# Altium schematic sheet [8]-IR_BACKLIGHT.SchDoc([8]-IR_BACKLIGHT)
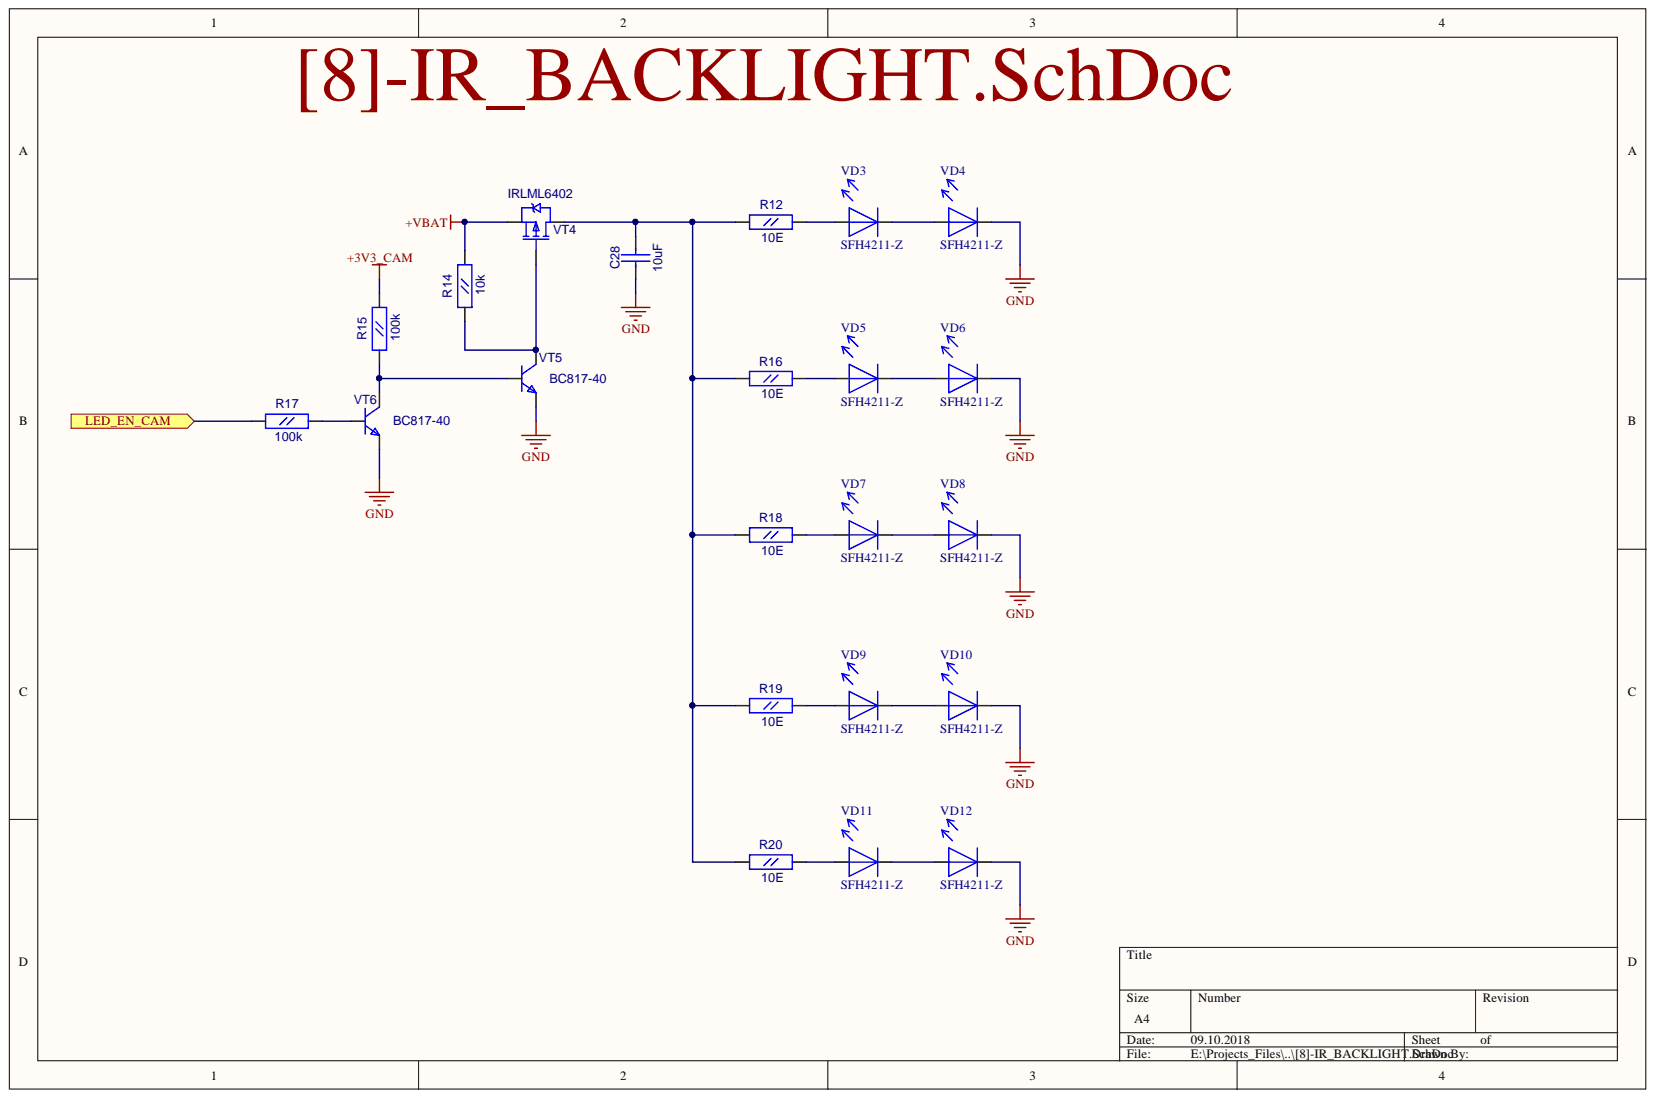
[diagram p0: [8]-IR_BACKLIGHT.SchDoc]

## Parts
C28  10uF  [CC0805]
R12  10E  [RC0603]
R14  10k  [RC0603]
R15  100k  [RC0603]
R16  10E  [RC0603]
R17  100k  [RC0603]
R18  10E  [RC0603]
R19  10E  [RC0603]
R20  10E  [RC0603]
VD3  SFH4211-Z  [LED_3528]
VD4  SFH4211-Z  [LED_3528]
VD5  SFH4211-Z  [LED_3528]
VD6  SFH4211-Z  [LED_3528]
VD7  SFH4211-Z  [LED_3528]
VD8  SFH4211-Z  [LED_3528]
VD9  SFH4211-Z  [LED_3528]
VD10  SFH4211-Z  [LED_3528]
VD11  SFH4211-Z  [LED_3528]
VD12  SFH4211-Z  [LED_3528]
VT4  IRLML6402  [SOT-23(123)]
VT5  BC817-40  [SOT-23(123)]
VT6  BC817-40  [SOT-23(123)]

## Ports
LED_EN_CAM

## Nets
+3V3_CAM: R15.2
+VBAT: R14.2 VT4.2
GND: C28.1 VD4.2 VD6.2 VD8.2 VD10.2 VD12.2 VT5.2 VT6.2
LED_EN_CAM: R17.1 ; port LED_EN_CAM
NetC28_2: C28.2 R12.1 R16.1 R18.1 R19.1 R20.1 VT4.3
NetR12_2: R12.2 VD3.1
NetR14_1: R14.1 VT4.1 VT5.3
NetR15_1: R15.1 VT5.1 VT6.3
NetR16_2: R16.2 VD5.1
NetR17_2: R17.2 VT6.1
NetR18_2: R18.2 VD7.1
NetR19_2: R19.2 VD9.1
NetR20_2: R20.2 VD11.1
NetVD3_2: VD3.2 VD4.1
NetVD5_2: VD5.2 VD6.1
NetVD7_2: VD7.2 VD8.1
NetVD9_2: VD9.2 VD10.1
NetVD11_2: VD11.2 VD12.1

## Library data of the parts
C28 = CC0805: Value: 10uF; Description: CC0805; Component Kind: Standard; Library Name: fizik_lib.SchLib
R12 R14-R20 = RC0603: Description: RC0603; Component Kind: Standard; Library Name: fizik_lib.SchLib
  R12 R16 R18-R20: Value: 10E
  R14: Value: 10k
  R15 R17: Value: 100k
VD3-VD12 = LED_3528: Value: SFH4211-Z; Component Kind: Standard; Library Name: fizik_lib.SchLib
VT4 = IRLML6402: HEXFET Power MOSFET; OriginalName: IRLML5103; ComponentField7: Published 8-Jun-2000; Value: IRLML6402; Component Kind: Standard; Library Name: fizik_lib.SchLib
VT5 VT6 = BC846BLT1: NPN 500ma transistor; ComponentField7: Published 29-Sep-2000; Value: BC817-40; Simulation: BC846BLT1; OriginalName: BC846BLT1; Component Kind: Standard; Library Name: fizik_lib.SchLib
